# Altium schematic sheet LTC_Hardware.SchDoc
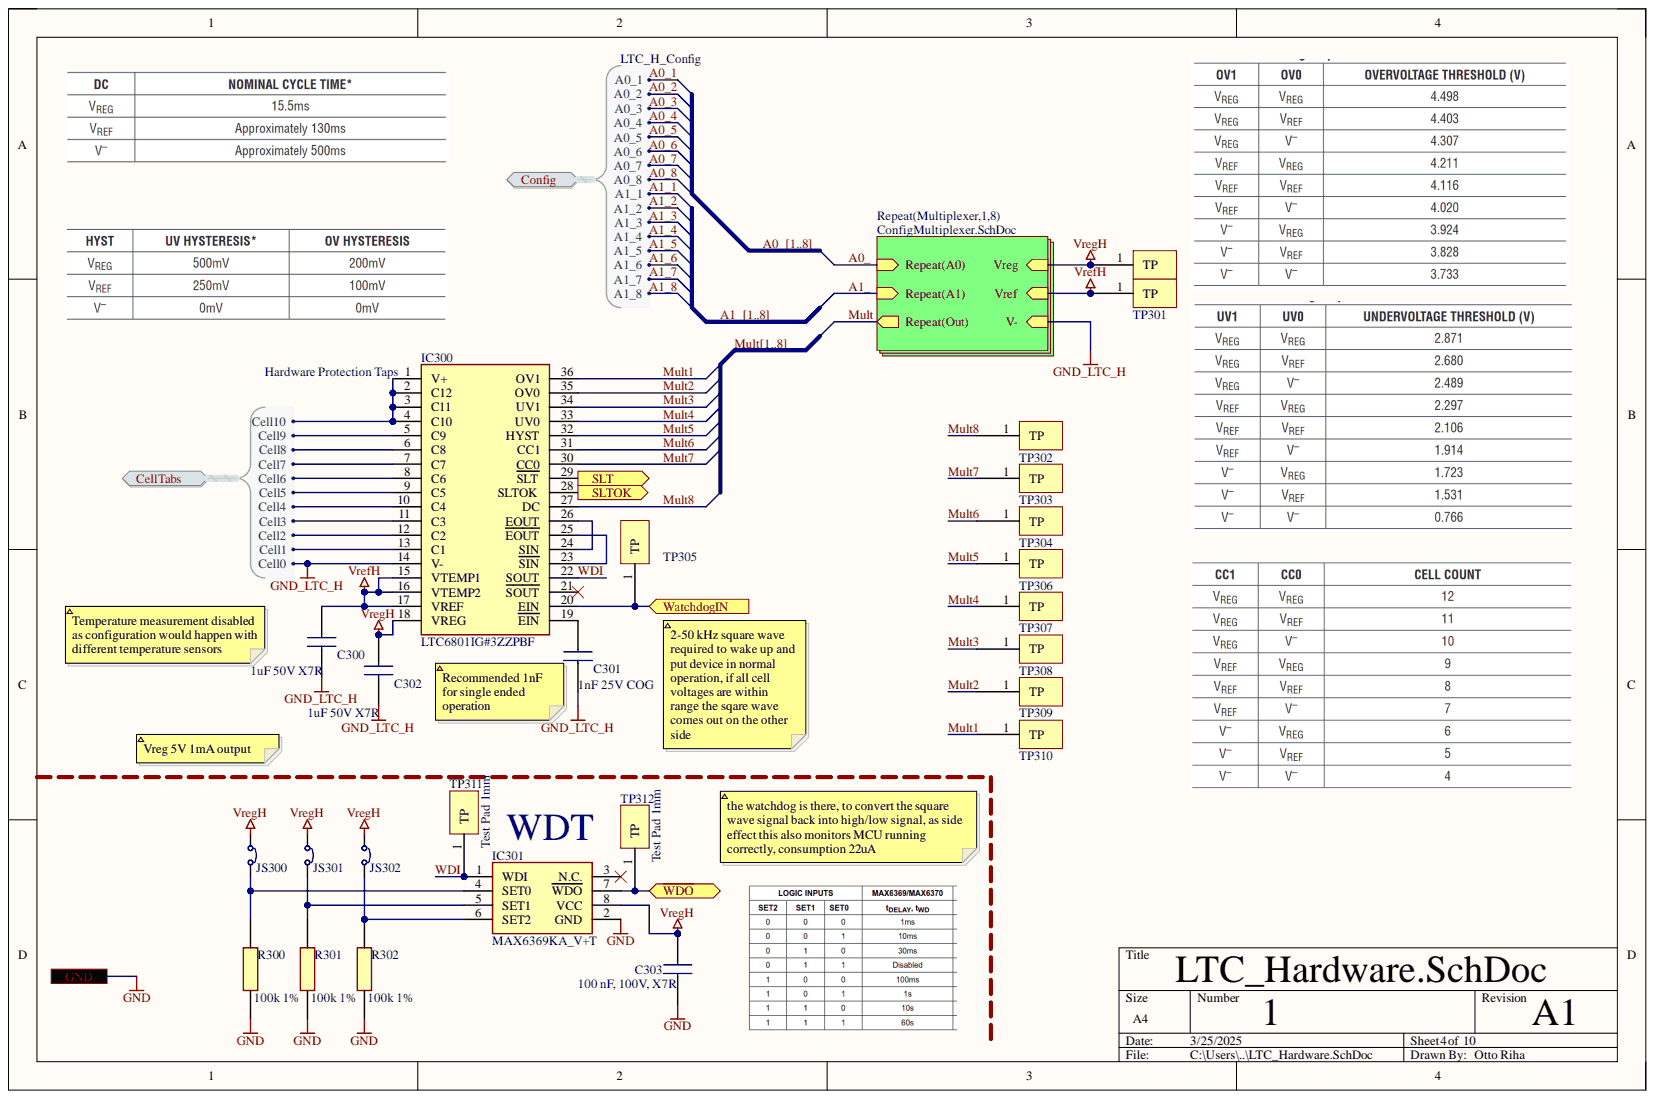
[diagram p0: LTC_Hardware.SchDoc]

## Parts
C300  1uF 50V X7R  value 1uF  [CAPC1608X90N]
C301  1nF 25V COG  value 1nF  [CAPC1608X90N]
C302  1uF 50V X7R  value 1uF  [CAPC1608X90N]
C303  100 nF, 100V, X7R  [MAJx16]
IC300  LTC6801IG#3ZZPBF  [SOP65P780X200-36N]
IC301  MAX6369KA_V+T  [SOT65P280X145-8N]
JS300  SolderJumper  [SolderJumper]  (value not drawn)
JS301  SolderJumper  [SolderJumper]  (value not drawn)
JS302  SolderJumper  [SolderJumper]  (value not drawn)
R300  100k 1%  value 100k  [RESC1608X55N]
R301  100k 1%  value 100k  [RESC1608X55N]
R302  100k 1%  value 100k  [RESC1608X55N]
TP300  Test Pad 1mm  [Test Pad 1mm-Footprint-1]
TP301  Test Pad 1mm  [Test Pad 1mm-Footprint-1]
TP302  Test Pad 1mm  [Test Pad 1mm-Footprint-1]
TP303  Test Pad 1mm  [Test Pad 1mm-Footprint-1]
TP304  Test Pad 1mm  [Test Pad 1mm-Footprint-1]
TP305  Test Pad 1mm  [Test Pad 1mm-Footprint-1]
TP306  Test Pad 1mm  [Test Pad 1mm-Footprint-1]
TP307  Test Pad 1mm  [Test Pad 1mm-Footprint-1]
TP308  Test Pad 1mm  [Test Pad 1mm-Footprint-1]
TP309  Test Pad 1mm  [Test Pad 1mm-Footprint-1]
TP310  Test Pad 1mm  [Test Pad 1mm-Footprint-1]
TP311  Test Pad 1mm  [Test Pad 1mm-Footprint-1]
TP312  Test Pad 1mm  [Test Pad 1mm-Footprint-1]

## Ports
CellTabs, CellTabs.Cell0, CellTabs.Cell1, CellTabs.Cell2, CellTabs.Cell3, CellTabs.Cell4, CellTabs.Cell5, CellTabs.Cell6, CellTabs.Cell7, CellTabs.Cell8, CellTabs.Cell9, CellTabs.Cell10, Config, Config.A0_1, Config.A0_2, Config.A0_3, Config.A0_4, Config.A0_5, Config.A0_6, Config.A0_7, Config.A0_8, Config.A1_1, Config.A1_2, Config.A1_3, Config.A1_4, Config.A1_5, Config.A1_6, Config.A1_7, Config.A1_8, GND, SLT, SLTOK, WatchdogIN, ~{WDO}

## Nets
VrefH: C300.1 IC300.15(VTEMP1) IC300.16(VTEMP2) IC300.17(VREF) TP301.1
VregH: C302.1 C303.2 IC300.18(VREG) IC301.8(VCC) JS300.2 JS301.2 JS302.2 TP300.1
GND: C303.1 IC301.2(GND) R300.1 R301.1 R302.1 ; port GND
GND_LTC_H: C300.2 C301.1 C302.2 IC300.14(V-) ; port CellTabs
Mult1: IC300.36(OV1) TP310.1  [also labelled Mult]
Mult2: IC300.35(OV0) TP309.1  [also labelled Mult]
Mult3: IC300.34(UV1) TP308.1  [also labelled Mult]
Mult4: IC300.33(UV0) TP307.1  [also labelled Mult]
Mult5: IC300.32(HYST) TP306.1  [also labelled Mult]
Mult6: IC300.31(CC1) TP304.1  [also labelled Mult]
Mult7: IC300.30(CC0) TP303.1  [also labelled Mult]
Mult8: IC300.27(DC) TP302.1  [also labelled Mult]
SLT: IC300.29(SLT) ; port SLT
SLTOK: IC300.28(SLTOK) ; port SLTOK
~{WDO}: IC301.7(WDO) TP312.1 ; port ~{WDO}
WatchdogIN: IC300.20(EIN) TP305.1 ; port WatchdogIN
WDI: IC300.22(SOUT) IC301.1(WDI) TP311.1
NetC301_2: C301.2 IC300.19(EIN)
NetIC300_1: IC300.1(V+) IC300.2(C12) IC300.3(C11) IC300.4(C10) ; port CellTabs
NetIC300_5: IC300.5(C9) ; port CellTabs
NetIC300_6: IC300.6(C8) ; port CellTabs
NetIC300_7: IC300.7(C7) ; port CellTabs
NetIC300_8: IC300.8(C6) ; port CellTabs
NetIC300_9: IC300.9(C5) ; port CellTabs
NetIC300_10: IC300.10(C4) ; port CellTabs
NetIC300_11: IC300.11(C3) ; port CellTabs
NetIC300_12: IC300.12(C2) ; port CellTabs
NetIC300_13: IC300.13(C1) ; port CellTabs
NetIC300_21: IC300.21(SOUT)
NetIC300_23: IC300.23(SIN) IC300.25(EOUT)
NetIC300_24: IC300.24(SIN) IC300.26(EOUT)
NetIC301_3: IC301.3(N.C.)
NetIC301_4: IC301.4(SET0) JS300.1 R300.2
NetIC301_5: IC301.5(SET1) JS301.1 R301.2
NetIC301_6: IC301.6(SET2) JS302.1 R302.2
A0_1: ; port Config  [also labelled A0_]
A0_2: ; port Config  [also labelled A0_]
A0_3: ; port Config  [also labelled A0_]
A0_4: ; port Config  [also labelled A0_]
A0_5: ; port Config  [also labelled A0_]
A0_6: ; port Config  [also labelled A0_]
A0_7: ; port Config  [also labelled A0_]
A0_8: ; port Config  [also labelled A0_]
A1_1: ; port Config  [also labelled A1_]
A1_2: ; port Config  [also labelled A1_]
A1_3: ; port Config  [also labelled A1_]
A1_4: ; port Config  [also labelled A1_]
A1_5: ; port Config  [also labelled A1_]
A1_6: ; port Config  [also labelled A1_]
A1_7: ; port Config  [also labelled A1_]
A1_8: ; port Config  [also labelled A1_]

## Library data of the parts
C300 C302 = 06035C105MAT2A: Capacitor 1uF 50V X7R; Manufacturer_Name: Kyocera AVX; Component Kind: Standard; Datasheet Link: https://componentsearchengine.com/Datasheets/1/06031A100FAT2A.pdf; Height: 0.9mm; Library Name: SamacSys.SchLib; Manufacturer_Part_Number: 06035C105MAT2A; Mouser Part Number: 581-06035C105MAT2A; Mouser Price/Stock: https://www.mouser.co.uk/ProductDetail/KYOCERA-AVX/06035C105MAT2A?qs=%252BdQmOuGyFcHMsevPtc6OZQ%3D%3D
  C302: Value: 1uF
C301 = 06033A102FAT2A: Capacitor 1nF 25V COG; Manufacturer_Name: Kyocera AVX; Component Kind: Standard; Datasheet Link: https://componentsearchengine.com/Datasheets/1/06031A100FAT2A.pdf; Height: 0.9mm; Library Name: SamacSys.SchLib; Manufacturer_Part_Number: 06033A102FAT2A; Mouser Part Number: 581-06033A102F; Mouser Price/Stock: https://www.mouser.com/Search/Refine.aspx?Keyword=581-06033A102F
C303 = MAJCH168BB7104KTEA01: Capacitor 100nF, 100V, X7R; Manufacturer_Name: TAIYO YUDEN; Arrow Part Number: MAJCH168BB7104KTEA01; Arrow Price/Stock: https://www.arrow.com/en/products/majch168bb7104ktea01/taiyo-yuden?utm_currency=USD&region=nac; Component Kind: Standard; Datasheet Link: https://ds.yuden.co.jp/TYCOMPAS/or/detail?pn=MAJCH168BB7104KTEA01; Height: 0.8mm; Library Name: SamacSys.SchLib; Manufacturer_Part_Number: MAJCH168BB7104KTEA01; Mouser Part Number: 963-MAJCH168BB7104KT; Mouser Price/Stock: https://www.mouser.co.uk/ProductDetail/TAIYO-YUDEN/MAJCH168BB7104KTEA01?qs=ZcfC38r4PovaTBrG0IdezQ%3D%3D; Supplier 1: Mouser; Supplier Part Number 1: 963-MAJCH168BB7104KT
IC300 = LTC6801IG#3ZZPBF: Integrated Circuit; Manufacturer_Name: Analog Devices; Arrow Part Number: LTC6801IG#3ZZPBF; Arrow Price/Stock: https://www.arrow.com/en/products/ltc6801ig3zzpbf/analog-devices; Component Kind: Standard; Datasheet Link: https://www.analog.com/LTC6801/datasheet; Height: 2mm; Manufacturer_Part_Number: LTC6801IG#3ZZPBF; Mouser Part Number: 584-LTC6801IG#3ZZPBF; Mouser Price/Stock: https://www.mouser.com/Search/Refine.aspx?Keyword=584-LTC6801IG%233ZZPBF
IC301 = MAX6369KA_V+T: Integrated Circuit; Manufacturer_Name: Analog Devices; Manufacturer_Part_Number: MAX6369KA/V+T; Arrow Part Number: MAX6369KA/V+T; Arrow Price/Stock: https://www.arrow.com/en/products/max6369kavt/maxim-integrated?region=nac; Component Kind: Standard; Datasheet Link: https://pdfserv.maximintegrated.com/en/ds/MAX6369-MAX6374.pdf; Height: 1.45mm; Library Name: SamacSys.SchLib; Mouser Part Number: 700-MAX6369KA/V+T; Mouser Price/Stock: https://www.mouser.co.uk/ProductDetail/Analog-Devices-Maxim-Integrated/MAX6369KA-V%2bT?qs=Mc2rGIXtnyXSLiZoc8BdSg%3D%3D
R300-R302 = CRCW0603100KFKEAC: Resistor 100k, 1%; Manufacturer_Name: Vishay; Arrow Part Number: CRCW0603100KFKEAC; Arrow Price/Stock: https://www.arrow.com/en/products/crcw0603100kfkeac/vishay?utm_currency=USD&region=nac; Component Kind: Standard; Datasheet Link: https://www.mouser.com/datasheet/2/427/crcwce3-1223726.pdf; Height: 0.55mm; Library Name: SamacSys.SchLib; Manufacturer_Part_Number: CRCW0603100KFKEAC; Mouser Part Number: 71-CRCW0603100KFKEAC; Mouser Price/Stock: https://www.mouser.co.uk/ProductDetail/Vishay-Dale/CRCW0603100KFKEAC?qs=E3Y5ESvWgWPxzfNYyMgX1w%3D%3D; Supplier 1: Mouser; Supplier Part Number 1: 71-CRCW0603100KFKEAC
TP300-TP312 = CMP-002-00031-3: 1mm radius circuilar test pad; Component Kind: Standard; Library Name: EPFL Racing Team
JS300-JS302 = SolderJumper: Component Kind: Standard; Library Name: SamacSys.SchLib
